# Altium schematic sheet [12] SD_MMC.SchDoc("[12] SD_MMC")
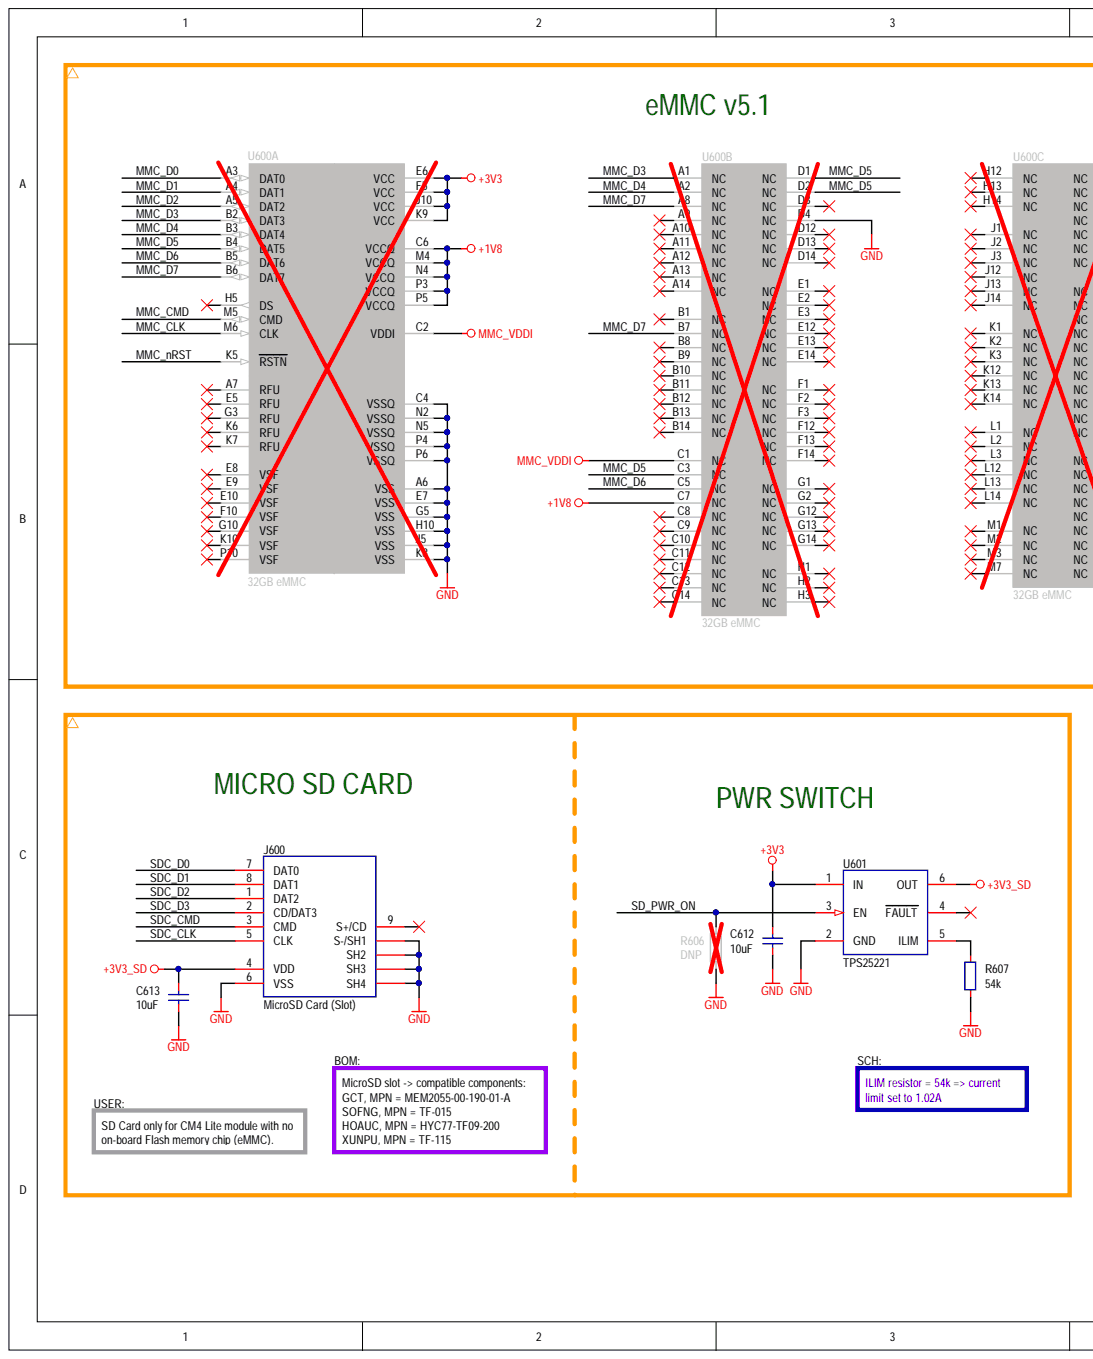
[diagram p0: [12] SD_MMC.SchDoc, left]
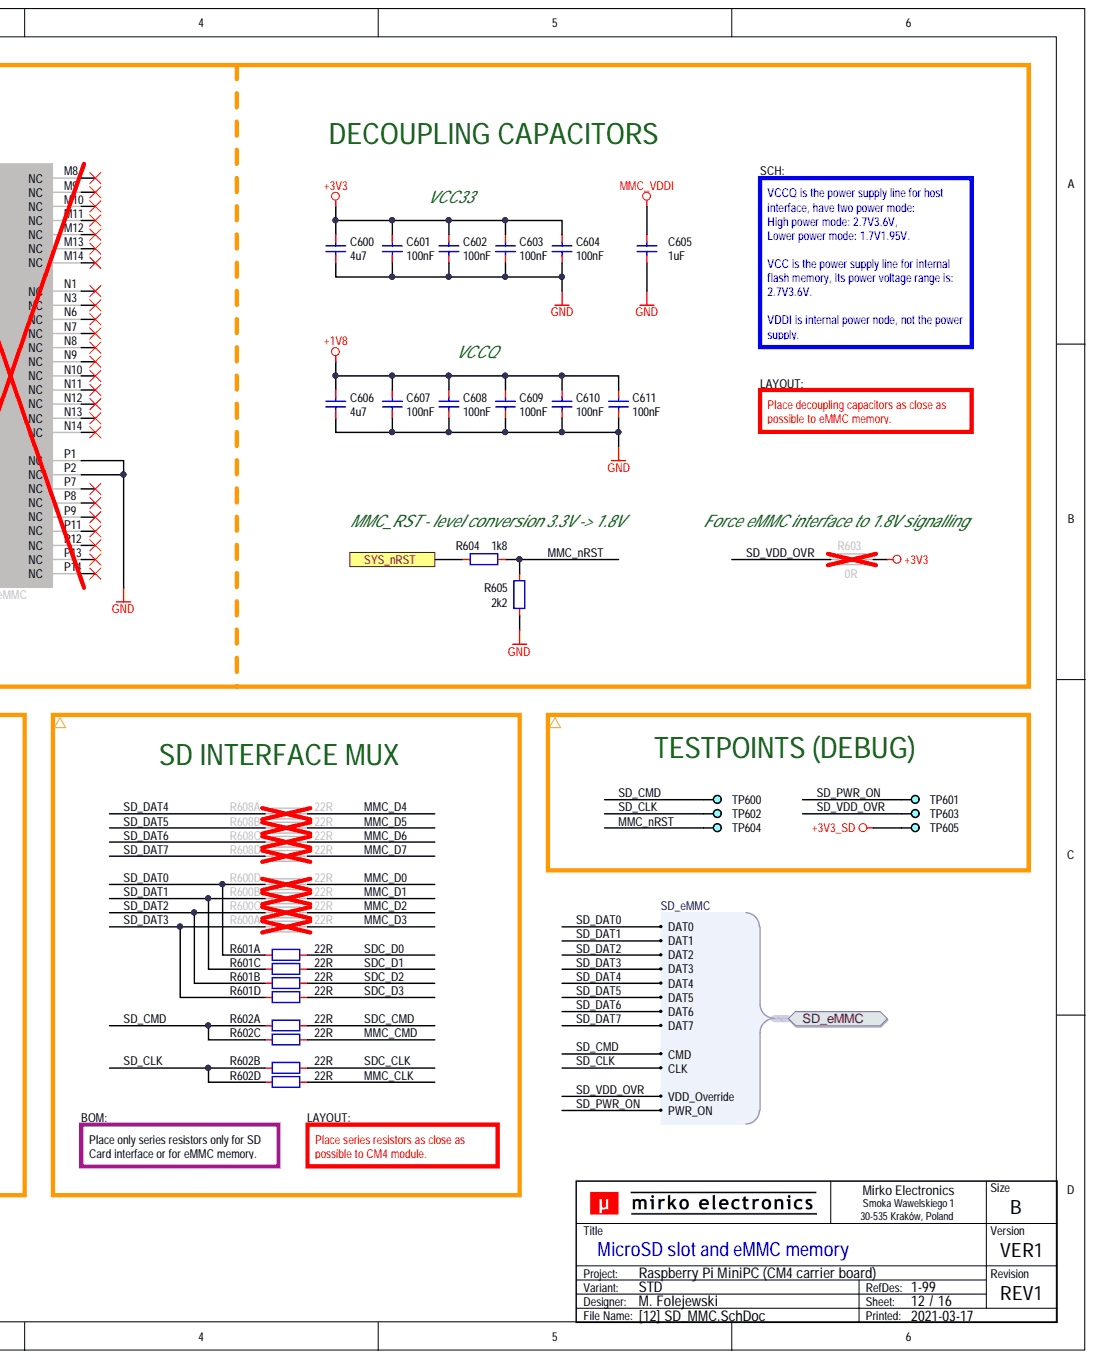
[diagram p1: [12] SD_MMC.SchDoc, right]

## Parts
C600  4u7  [CAP_0603_R]
C601  100nF  [CAP_0603_R]
C602  100nF  [CAP_0603_R]
C603  100nF  [CAP_0603_R]
C604  100nF  [CAP_0603_R]
C605  1uF  [CAP_0603_R]
C606  4u7  [CAP_0603_R]
C607  100nF  [CAP_0603_R]
C608  100nF  [CAP_0603_R]
C609  100nF  [CAP_0603_R]
C610  100nF  [CAP_0603_R]
C611  100nF  [CAP_0603_R]
C612  10uF  [CAP_0603_R]
C613  10uF  [CAP_0603_R]
J600  MicroSD Card (Slot)  [CON_GCT_MEM2055_R]
R600  22R  [RES_YC124_R]  (parts A,B,C,D)
R601  22R  [RES_YC124_R]  (parts A,B,C,D)
R602  22R  [RES_YC124_R]  (parts A,B,C,D)
R603  0R  [RES_0603_R]
R604  1k8  [RES_0603_R]
R605  2k2  [RES_0603_R]
R606  DNP  [RES_0603_R]
R607  54k  [RES_0603_R]
R608  22R  [RES_YC124_R]  (parts A,B,C,D)
TP600  DEBUG  [PCB_TP_DBG_50]  (value not drawn)  (no BOM)
TP601  DEBUG  [PCB_TP_DBG_50]  (no BOM)
TP602  DEBUG  [PCB_TP_DBG_50]  (value not drawn)  (no BOM)
TP603  DEBUG  [PCB_TP_DBG_50]  (value not drawn)  (no BOM)
TP604  DEBUG  [PCB_TP_DBG_50]  (value not drawn)  (no BOM)
TP605  DEBUG  [PCB_TP_DBG_50]  (value not drawn)  (no BOM)
U600  32GB eMMC  Memory Size: 32GB  Memory Controller: eMMC  [ICC_FBGA153_1300X1150X80_P50]  (parts A,B,C)
U601  TPS25221  [PKG_SOT23-6]

## Ports
SD_eMMC, SD_eMMC.CLK, SD_eMMC.CMD, SD_eMMC.DAT0, SD_eMMC.DAT1, SD_eMMC.DAT2, SD_eMMC.DAT3, SD_eMMC.DAT4, SD_eMMC.DAT5, SD_eMMC.DAT6, SD_eMMC.DAT7, SD_eMMC.PWR_ON, SD_eMMC.VDD_Override, SYS_nRST

## Nets
+1V8: C606.2 C607.2 C608.2 C609.2 C610.2 C611.2 U600.C6(VCCQ) U600.C7(NC) U600.M4(VCCQ) U600.N4(VCCQ) U600.P3(VCCQ) U600.P5(VCCQ)
+3V3: C600.2 C601.2 C602.2 C603.2 C604.2 C612.2 R603.2 U600.E6(VCC) U600.F5(VCC) U600.J10(VCC) U600.K9(VCC) U601.1(IN)
+3V3_SD: C613.2 J600.4(VDD) TP605.1 U601.6(OUT)
GND: C600.1 C601.1 C602.1 C603.1 C604.1 C605.1 C606.1 C607.1 C608.1 C609.1 C610.1 C611.1 C612.1 C613.1 J600.6(VSS) J600.SH1(S-/SH1) J600.SH2 J600.SH3 J600.SH4 R605.1 R606.1 R607.1 U600.A6(VSS) U600.C4(VSSQ) U600.D4(NC) U600.E7(VSS) U600.G5(VSS) U600.H10(VSS) U600.J5(VSS) U600.K8(VSS) U600.N2(VSSQ) U600.N5(VSSQ) U600.P1(NC) U600.P2(NC) U600.P4(VSSQ) U600.P6(VSSQ) U601.2(GND)
MMC_VDDI: C605.2 U600.C1(NC) U600.C2(VDDI)
MMC_CLK: R602.5 U600.M6(CLK)
MMC_CMD: R602.6 U600.M5(CMD)
MMC_D0: R600.5 U600.A3(DAT0)
MMC_D1: R600.7 U600.A4(DAT1)
MMC_D2: R600.6 U600.A5(DAT2)
MMC_D3: R600.8 U600.A1(NC) U600.B2(DAT3)
MMC_D4: R608.8 U600.A2(NC) U600.B3(DAT4)
MMC_D5: R608.7 U600.B4(DAT5) U600.C3(NC) U600.D1(NC) U600.D2(NC)
MMC_D6: R608.6 U600.B5(DAT6) U600.C5(NC)
MMC_D7: R608.5 U600.A8(NC) U600.B6(DAT7) U600.B7(NC)
MMC_nRST: R604.1 R605.2 TP604.1 U600.K5(RSTN)
SD_CLK: R602.2 R602.4 TP602.1 ; port SD_eMMC
SD_CMD: R602.1 R602.3 TP600.1 ; port SD_eMMC
SD_DAT0: R600.4 R601.1 ; port SD_eMMC
SD_DAT1: R600.2 R601.3 ; port SD_eMMC
SD_DAT2: R600.3 R601.2 ; port SD_eMMC
SD_DAT3: R600.1 R601.4 ; port SD_eMMC
SD_DAT4: R608.1 ; port SD_eMMC
SD_DAT5: R608.2 ; port SD_eMMC
SD_DAT6: R608.3 ; port SD_eMMC
SD_DAT7: R608.4 ; port SD_eMMC
SD_PWR_ON: R606.2 TP601.1 U601.3(EN) ; port SD_eMMC
SD_VDD_OVR: R603.1 TP603.1 ; port SD_eMMC
SDC_CLK: J600.5(CLK) R602.7
SDC_CMD: J600.3(CMD) R602.8
SDC_D0: J600.7(DAT0) R601.8
SDC_D1: J600.8(DAT1) R601.6
SDC_D2: J600.1(DAT2) R601.7
SDC_D3: J600.2(CD/DAT3) R601.5
SYS_nRST: R604.2 ; port SYS_nRST
NetR607_2: R607.2 U601.5(ILIM)

Not connected: J600 9(S+/CD); U600 A7(RFU) A9(NC) A10(NC) A11(NC) A12(NC) A13(NC) A14(NC) B1(NC) B8(NC) B9(NC) B10(NC) B11(NC) B12(NC) B13(NC) B14(NC) C8(NC) C9(NC) C10(NC) C11(NC) C12(NC) C13(NC) C14(NC) D3(NC) D12(NC) D13(NC) D14(NC) E1(NC) E2(NC) E3(NC) E5(RFU) E8(VSF) E9(VSF) E10(VSF) E12(NC) E13(NC) E14(NC) F1(NC) F2(NC) F3(NC) F10(VSF) F12(NC) F13(NC) F14(NC) G1(NC) G2(NC) G3(RFU) G10(VSF) G12(NC) G13(NC) G14(NC) H1(NC) H2(NC) H3(NC) H5(DS) H12(NC) H13(NC) H14(NC) J1(NC) J2(NC) J3(NC) J12(NC) J13(NC) J14(NC) K1(NC) K2(NC) K3(NC) K6(RFU) K7(RFU) K10(VSF) K12(NC) K13(NC) K14(NC) L1(NC) L2(NC) L3(NC) L12(NC) L13(NC) L14(NC) M1(NC) M2(NC) M3(NC) M7(NC) M8(NC) M9(NC) M10(NC) M11(NC) M12(NC) M13(NC) M14(NC) N1(NC) N3(NC) N6(NC) N7(NC) N8(NC) N9(NC) N10(NC) N11(NC) N12(NC) N13(NC) N14(NC) P7(NC) P8(NC) P9(NC) P10(VSF) P11(NC) P12(NC) P13(NC) P14(NC); U601 4(FAULT)

## Library data of the parts
C600 C605 C606 C612 C613 = CAP-0023: Capacitor; MLCC; GENERIC; TBD; TBD; TBD; TBD; SMD; 0603 (1608);; Database Table Name: CAPACITOR$; Voltage Rating: TBD; Tolerance: TBD; ROHS Status: TBD; Packaging Type: TBD; Package (Case): 0603 (1608); Operating Temperature: TBD; MPN: GENERIC; Mounting Type: SMD; Manufacturer: GENERIC; ID: CAP-0023; Features: TBD; Dielectric: TBD; Component Type: Capacitor; Component Subtype: MLCC; Component Kind: Standard; Component Height: TBD; Component Body: TBD; Capacitance: GENERIC; Library Name: MirFol_db_local.DbLib
  C600 C606: Value: 4u7
  C605: Value: 1uF
  C612 C613: Value: 10uF
C601-C604 C607-C611 = CAP-0008: Capacitor; MLCC; 100nF; TBD; TBD; TBD; TBD; SMD; 0603 (1608);; Database Table Name: CAPACITOR$; Voltage Rating: TBD; Value: 100nF; Tolerance: TBD; ROHS Status: TBD; Packaging Type: TBD; Package (Case): 0603 (1608); Operating Temperature: TBD; MPN: TBD; Mounting Type: SMD; Manufacturer: TBD; ID: CAP-0008; Features: TBD; Dielectric: TBD; Component Type: Capacitor; Component Subtype: MLCC; Component Kind: Standard; Component Height: TBD; Component Body: TBD; Capacitance: 100nF; Library Name: MirFol_db_local.DbLib
J600 = CON_GCT_MEM2055: Connector; MicroSD Card Connector;; MPN: MEM2055-00-190-01-A; Manufacturer: GCT; Value: MicroSD Card (Slot); Component Kind: Standard; Library Name: MirFol_lib.SchLib
R600-R602 R608 = RES_ARR_X4_A2: Resistor; Fixed; Array X4;; MPN: YC124-JR-0722RL; Manufacturer: Yageo Corporation; Value: 22R; Component Kind: Standard; Library Name: MirFol_lib.SchLib
R603 = RES-0052: Resistor; Thick Film; 0R; 1%; TBD; TBD; TBD; Standard; SMD; 0603 (1608);; Database Table Name: RESISTOR$; Component Body: 1.60 x 0.80mm; Voltage Rating: TBD; Value: 0R; Tolerance: 1%; TCR: TBD; ROHS Status: TBD; Resistance: 0R; Power Rating: TBD; Packaging Type: TBD; Package (Case): 0603 (1608); Operating Temperature: TBD; MPN: TBD; Mounting Type: SMD; Manufacturer: TBD; ID: RES-0052; Features: Standard; Component Type: Resistor; Component Subtype: Thick Film; Component Kind: Standard; Component Height: 0.45mm; Library Name: MirFol_db_local.DbLib
R604 = RES-0054: Resistor; Thick Film; GENERIC; TBD; TBD; TBD; TBD; TBD; SMD; 0603 (1608);; Resistance: 54k; Database Table Name: RESISTOR$; Component Body: 1.60 x 0.80mm; Voltage Rating: TBD; Value: 1k8; Tolerance: TBD; TCR: TBD; ROHS Status: TBD; Power Rating: TBD; Packaging Type: TBD; Package (Case): 0603 (1608); Operating Temperature: TBD; MPN: GENERIC; Mounting Type: SMD; Manufacturer: GENERIC; ID: RES-0054; Features: TBD; Component Type: Resistor; Component Subtype: Thick Film; Component Kind: Standard; Component Height: TBD; Library Name: MirFol_db_local.DbLib
R605 = RES-0033: Resistor; Thick Film; 2k2; 1%; 100mW; 100PPM; 75V; Standard; SMD; 0603 (1608);; MPN: TBD; Manufacturer: Yageo Corporation; Packaging Type: Tape & Reel; Database Table Name: RESISTOR$; Component Body: 1.60 x 0.80mm; Voltage Rating: 75V; Value: 2k2; Tolerance: 1%; TCR: 100PPM; ROHS Status: RoHS Compliant; Resistance: 2k2; Power Rating: 100mW; Package (Case): 0603 (1608); Operating Temperature: -55°C to +155°C; Mounting Type: SMD; ID: RES-0033; Features: Standard; Component Type: Resistor; Component Subtype: Thick Film; Component Kind: Standard; Component Height: 0.45mm; Library Name: MirFol_db_local.DbLib
R606 = RES-0051: Resistor; Thick Film; DNP; TBD; TBD; TBD; TBD; DO NOT PLACE; SMD; 0603 (1608);; Database Table Name: RESISTOR$; Component Body: 1.60 x 0.80mm; Voltage Rating: TBD; Value: DNP; Tolerance: TBD; TCR: TBD; ROHS Status: TBD; Resistance: DNP; Power Rating: TBD; Packaging Type: TBD; Package (Case): 0603 (1608); Operating Temperature: TBD; MPN: TBD; Mounting Type: SMD; Manufacturer: TBD; ID: RES-0051; Features: DO NOT PLACE; Component Type: Resistor; Component Subtype: Thick Film; Component Kind: Standard; Component Height: TBD; Library Name: MirFol_db_local.DbLib
R607 = RES-0054: Resistor; Thick Film; GENERIC; TBD; TBD; TBD; TBD; TBD; SMD; 0603 (1608);; Database Table Name: RESISTOR$; Component Body: 1.60 x 0.80mm; Voltage Rating: TBD; Value: 54k; Tolerance: TBD; TCR: TBD; ROHS Status: TBD; Resistance: 54k; Power Rating: TBD; Packaging Type: TBD; Package (Case): 0603 (1608); Operating Temperature: TBD; MPN: GENERIC; Mounting Type: SMD; Manufacturer: GENERIC; ID: RES-0054; Features: TBD; Component Type: Resistor; Component Subtype: Thick Film; Component Kind: Standard; Component Height: TBD; Library Name: MirFol_db_local.DbLib
TP600-TP605 = PCB_TP_DBG: PCB Marking; Test Point (Debug);; Value: DEBUG; Library Name: MirFol_lib.SchLib
U600 = MEM-0001: IC; eMMC Memory; 32GB; 256Gb x1; NAND Flash; eMMC; eMMC 5.1; 200 MHz; SMD; FBGA-153;; MPN: NCEMASLD-32G; Manufacturer: FORESEE (Longsys Electronics); Power Supply #2: 1.7 – 1.95 V; 2.7 – 3.6 V; Power Supply #1: 2.7 – 3.6 V; Pin Pitch: 0.5 mm; Packaging Type: Unknown; Data Bus Width: 1bit (default); 4bits; 8bits; Database Table Name: IC (MEMORY)$; Component Body: 11.50 x 13.00 mm; Clock Interface: 200 MHz; Value: 32GB eMMC; ROHS Status: RoHS Compliant; Pin Count: 153; Package (Case): FBGA-153; Operating Temperature: -25°C to +85°C; Mounting Type: SMD; Memory Type: NAND Flash; Memory Standard: eMMC 5.1; Memory Organization: 256Gb x1; ID: MEM-0001; Features: Hardware ECC engine; S.M.A.R.T. Health Report; HS400; Component Type: IC; Component Subtype: eMMC Memory; Component Kind: Standard; Component Height: 1.00 mm; Library Name: MirFol_db_local.DbLib
U601 = ICC_TXI_TPS25221_DBV: IC; Current Limited Switch;; MPN: TPS25221DBV; Manufacturer: Texas Instruments; Value: TPS25221; Component Kind: Standard; Library Name: MirFol_lib.SchLib
